# Altium schematic sheet MAX232.SchDoc
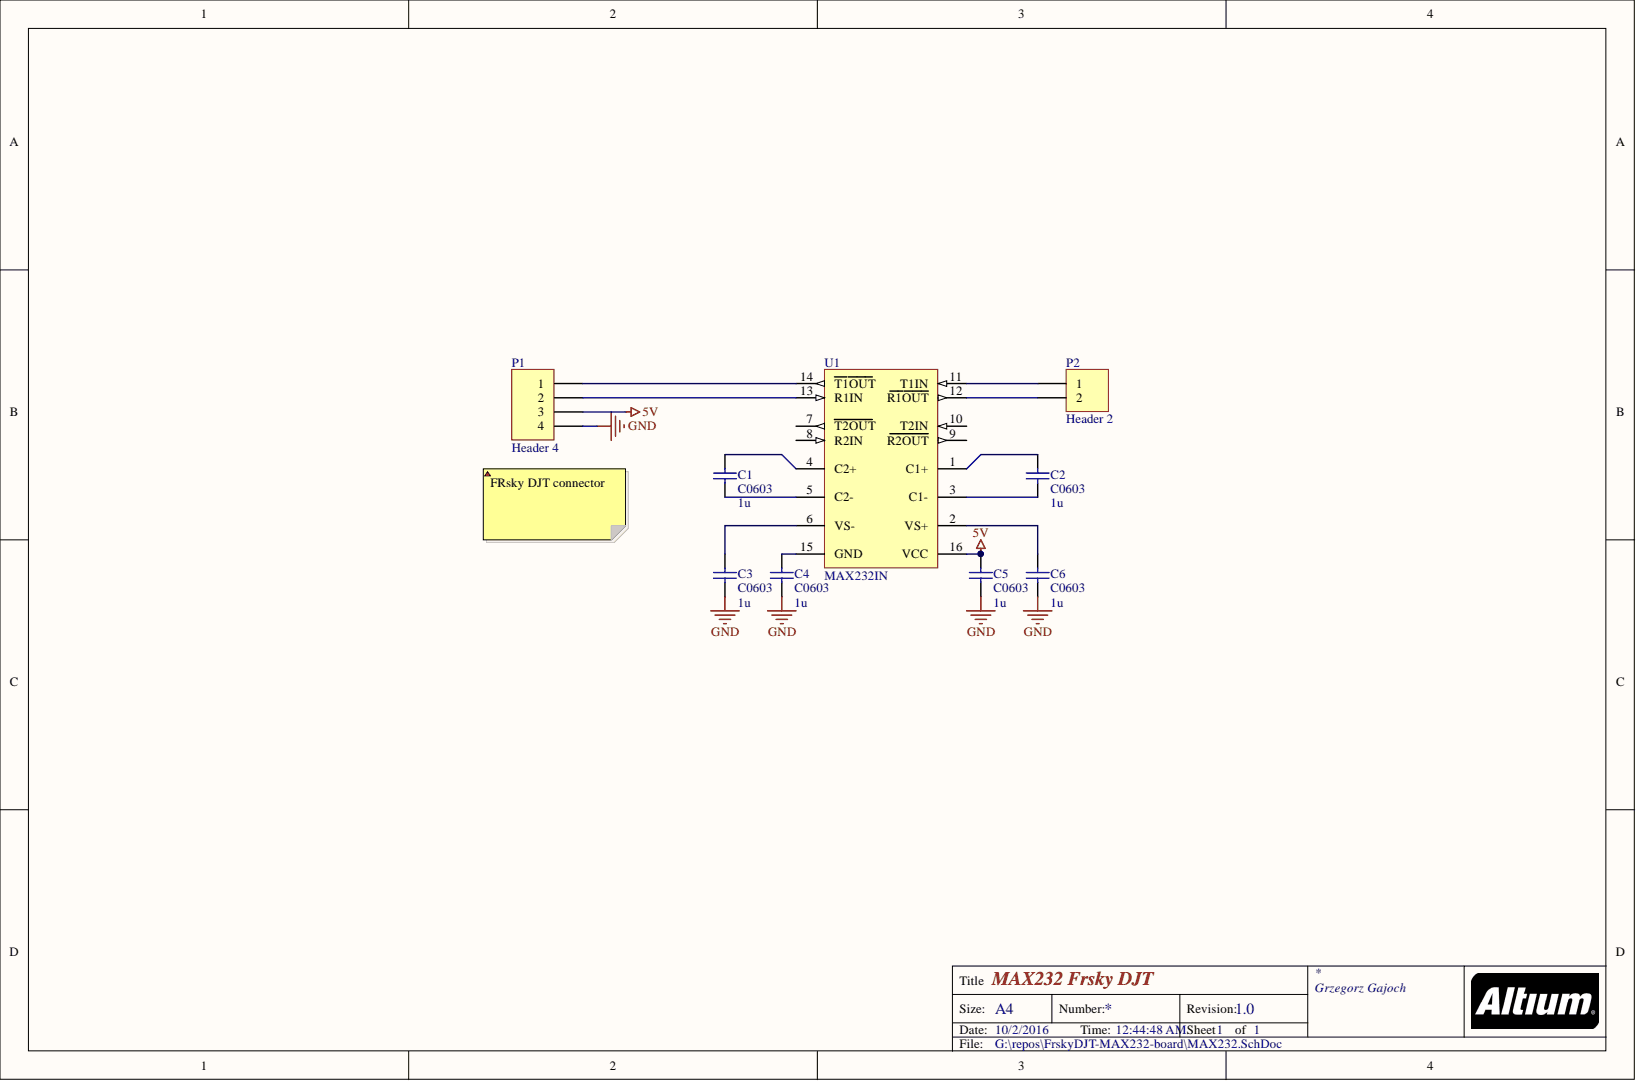
[diagram p0: MAX232.SchDoc]

## Parts
C1  1u  [C0603]
C2  1u  [C0603]
C3  1u  [C0603]
C4  1u  [C0603]
C5  1u  [C0603]
C6  1u  [C0603]
P1  Header 4  [HEADER 1X4 FEMALE]
P2  Header 2  [HEADER 1X2H Male]
U1  MAX232IN  [DIP16]

## Nets
5V: C5.2 P1.3 U1.16(VCC)
GND: C3.1 C4.1 C5.1 C6.1 P1.4
NetC1_1: C1.1 U1.5(C2-)
NetC1_2: C1.2 U1.4(C2+)
NetC2_1: C2.1 U1.3(C1-)
NetC2_2: C2.2 U1.1(C1+)
NetC3_2: C3.2 U1.6(VS-)
NetC4_2: C4.2 U1.15(GND)
NetC6_2: C6.2 U1.2(VS+)
NetP1_1: P1.1 U1.14(T1OUT)
NetP1_2: P1.2 U1.13(R1IN)
NetP2_1: P2.1 U1.11(T1IN)
NetP2_2: P2.2 U1.12(R1OUT)

Not connected: U1 7(T2OUT) 8(R2IN) 9(R2OUT) 10(T2IN)

## Library data of the parts
C1-C6 = Cap: Generic capacitor; Component Kind: Standard; Library Name: _RLC.IntLib; Packge: C0603; Value: 1u
P1 = Header 4: Header, 4-Pin; Component Kind: Standard; LatestRevisionDate: 17-Jul-2002; LatestRevisionNote: Re-released for DXP Platform.; Library Name: _Connectors.IntLib; Publisher: Altium Limited
P2 = Header 2: Header, 2-Pin; Component Kind: Standard; LatestRevisionDate: 17-Jul-2002; LatestRevisionNote: Re-released for DXP Platform.; Library Name: _Connectors.IntLib; Publisher: Altium Limited
U1 = MAX232IN: Dual EIA-232 Driver / Receiver, -40 to 85 degC, 16-Pin PDIP (N), Pb-Free (RoHS); Drivers Per Package: 2; ESD HBM(kV): +/-2; ICC(Max)(mA): 10; RS-232: TRUE; SubFamily: RS-232; Supply Voltage(s)(V): 5; Component Kind: Standard; ComponentLink1Description: Manufacturer URL; ComponentLink1URL: www.ti.com; ComponentLink2Description: Datasheet; ComponentLink2URL: http://www.ti.com/general/docs/lit/getliterature.tsp?genericPartNumber=MAX232&fileType=pdf; ComponentLink3Description: Package Specification; ComponentLink3URL: http://www.ti.com/litv/pdf/mpdi002c; DatasheetVersion: SLLS047L; PackageDescription: 16-Pin Plastic Dual-In-Line Package, Row Spacing 7.62 mm, Pitch 2.54 mm; PackageReference: N16; PackageVersion: revE, Dec-2002; Receivers Per Package: 2; Single Supply: TRUE; Library Name: _Microcircuits.IntLib
